# Altium schematic sheet Э3("All Documents",Physical)
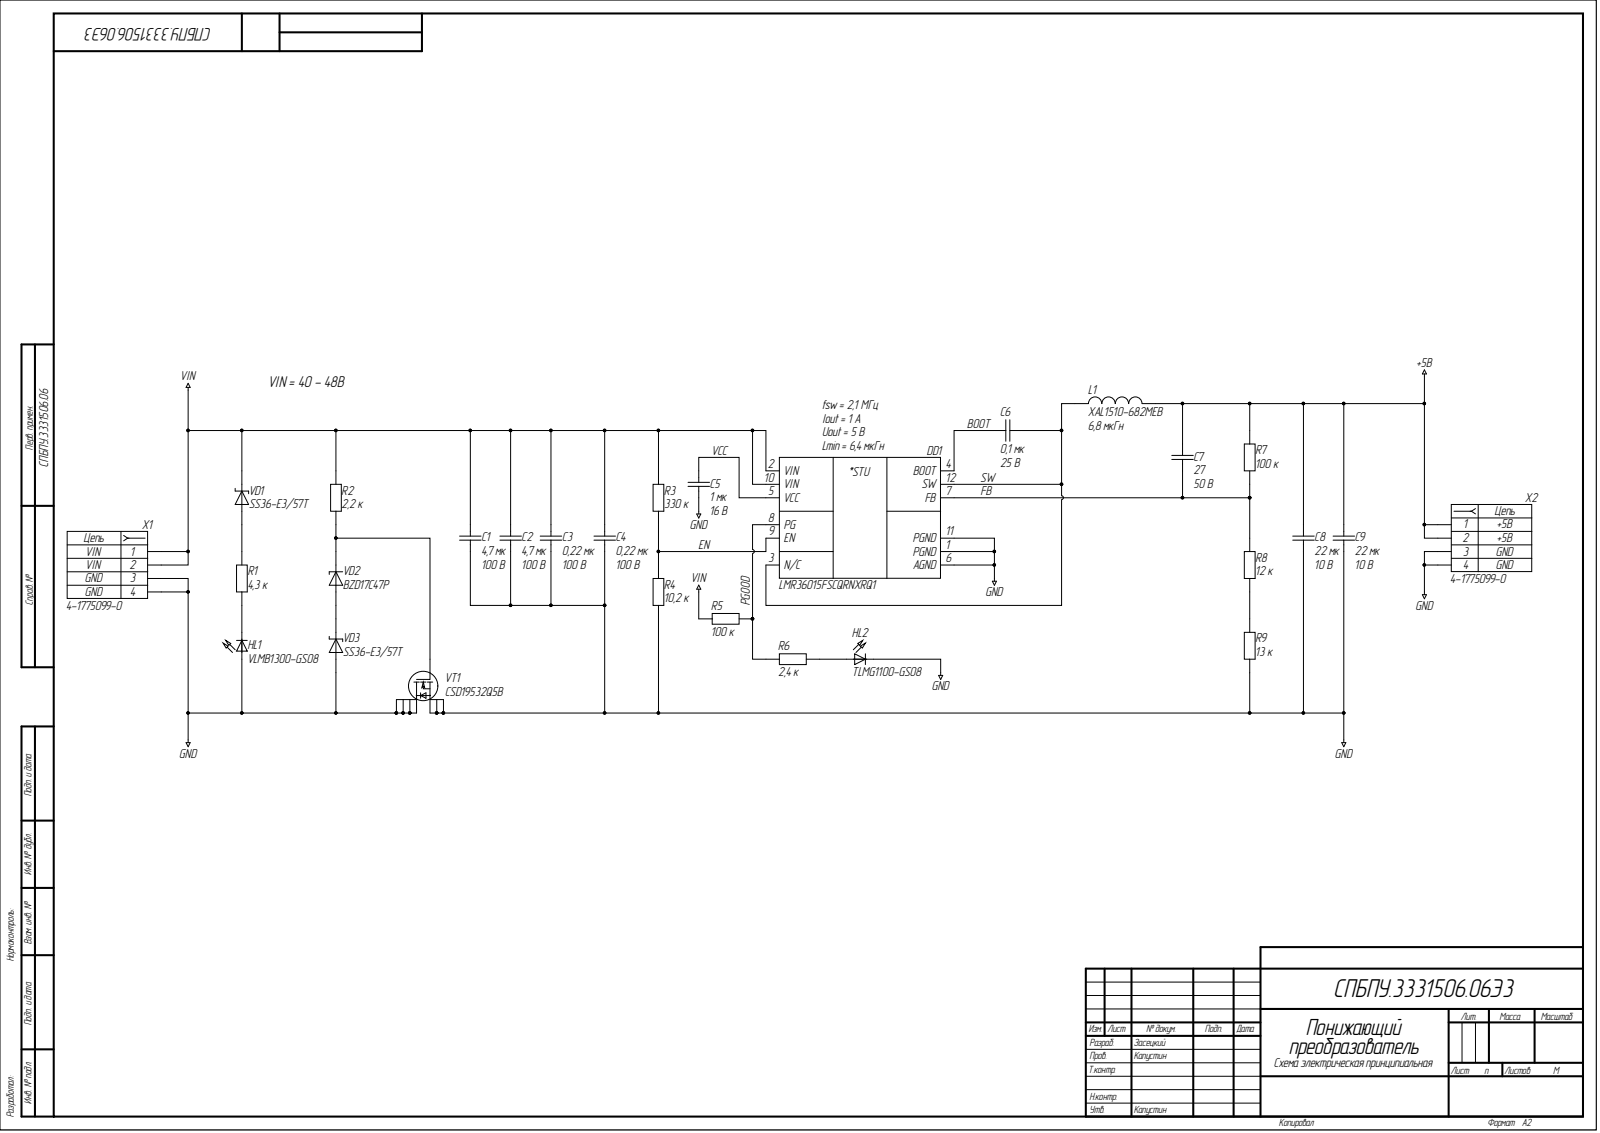
[diagram p0: Э3("All Documents",Physical)]

## Parts
C1  4,7 мк  [C1210]
C2  4,7 мк  Номинальное напряжение: 100 В  [C1210]
C3  0,22 мк  Номинальное напряжение: 100 В  [C0805]
C4  0,22 мк  Номинальное напряжение: 100 В  [C0805]
C5  1 мк  Номинальное напряжение: 16 В  [C0603]
C6  0,1 мк  Номинальное напряжение: 25 В  [C0603]
C7  27  Номинальное напряжение: 50 В  [C0402]
C8  22 мк  [C1206]
C9  22 мк  Номинальное напряжение: 10 В  [C1206]
DD1  LMR36015FSCQRNXRQ1  [LMR36015-Q1]
HL1  VLMB1300-GS08  [LED]
HL2  TLMG1100-GS08  [LED_GREEN]
L1  XAL1510-682MEB  Номинал: 6,8 мкГн  [XAL-2-1620X1520X1100]
R1  4,3 к  [R0603]
R2  2,2 к  [R0603]
R3  330 к  [R0603]
R4  10,2 к  [R0603]
R5  100 к  [R0603]
R6  2,4 к  [R0603]
R7  100 к  [R0603]
R8  12 к  [R0603]
R9  13 к  [R0603]
VD1  SS36-E3/57T  [DO-214AB]
VD2  BZD17C47P  [DO-219AB]
VD3  SS36-E3/57T  [DO-214AB]
VT1  CSD19532Q5B  [SON-8]
X1  4-1775099-0  Contact_4: GND  Contact_2: VIN  [4-1775099-0]
X2  4-1775099-0  Contact_4: GND  Contact_2: +5В  [4-1775099-0]

## Nets
+5В: C7.1 C8.1 C9.1 L1.2 R7.1 X2.1 X2.2
GND: C1.2 C2.2 C3.2 C4.2 C5.2 C8.2 C9.2 DD1.1(PGND) DD1.6(AGND) DD1.11(PGND) HL1.1 HL2.2 R4.1 R9.1 VD3.2 VT1.D VT1.S X1.3 X1.4 X2.3 X2.4
VIN: C1.1 C2.1 C3.1 C4.1 DD1.2(VIN) DD1.10(VIN) R2.2 R3.2 R5.1 VD1.1 X1.1 X1.2
BOOT: C6.2 DD1.4(BOOT)
EN: DD1.9(EN) R3.1 R4.2
FB: C7.2 DD1.7(FB) R7.2 R8.2
PGOOD: DD1.8(PG) R5.2 R6.1
SW: C6.1 DD1.3(N/C) DD1.12(SW) L1.1
VCC: C5.1 DD1.5(VCC)
NetHL1_2: HL1.2 R1.1
NetHL2_1: HL2.1 R6.2
NetR1_2: R1.2 VD1.2
NetR2_1: R2.1 VD2.1 VT1.G
NetR8_1: R8.1 R9.2
NetVD2_2: VD2.2 VD3.1

## Library data of the parts
C1 = c0119: =Описание; Фамилия: Засецкий; Температурный коэффициент емкости: X7R; Рабочие температуры: от –55 °С до +125 °С; Производитель: Samsung electro-mechanics; Отклонение: ±10 %; Описание: Конденсатор чип 1210 4,7 мкФ 100 В ±10 %; Номинальное напряжение: 100 В; Наименование: CL32B475KCIZNWE; Корпус: 1210; Database Table Name: Конденсаторы$; Номинал: 4,7 мк; Напряжение: 100 В; ID: c0119; HelpURL: http://product.samsungsem.com/mlcc/CL32B475KCIZNW.do; Description: Конденсатор чип 1210 4,7 мкФ 100 В ±10 %; Component Kind: Standard; Library Name: Database_Students.DbLib
C2 = c0119: =Описание; Фамилия: Засецкий; Температурный коэффициент емкости: X7R; Рабочие температуры: от –55 °С до +125 °С; Производитель: Samsung electro-mechanics; Отклонение: ±10 %; Описание: Конденсатор чип 1210 4,7 мкФ 100 В ±10 %; Наименование: CL32B475KCIZNWE; Корпус: 1210; Database Table Name: Конденсаторы$; Номинал: 4,7 мк; Напряжение: 100 В; ID: c0119; HelpURL: http://product.samsungsem.com/mlcc/CL32B475KCIZNW.do; Description: Конденсатор чип 1210 4,7 мкФ 100 В ±10 %; Component Kind: Standard; Library Name: Database_Students.DbLib
C3 C4 = c0120: =Описание; Фамилия: Засецкий; Температурный коэффициент емкости: X7R; Рабочие температуры: от –55 °С до +125 °С; Производитель: Samsung electro-mechanics; Отклонение: ±10 %; Описание: Конденсатор чип 0805 0,22 мкФ 100 В ±10 %; Наименование: CL21B224KCFSFNE; Корпус: 0805; Database Table Name: Конденсаторы$; Номинал: 0,22 мк; Напряжение: 100 В; ID: c0120; HelpURL: http://product.samsungsem.com/mlcc/CL21B224KCFSFN.do; Description: Конденсатор чип 0805 0,22 мкФ 100 В ±10 %; Component Kind: Standard; Library Name: Database_Students.DbLib
C5 = c0063: =Описание; Фамилия: Паньков; Температурный коэффициент емкости: X7R; Рабочие температуры: от –55 °С до +125 °С; Производитель: YAGEO Corp.; Отклонение: ±10 %; Описание: Конденсатор чип 0603 1 мкФ 16 В ±10 %; Наименование: CC0603KRX7R7BB105; Корпус: 0603; Database Table Name: Конденсаторы$; Номинал: 1 мк; Напряжение: 16 В; ID: c0063; HelpURL: https://www.yageo.com/upload/media/product/products/datasheet/mlcc/UPY-GPHC_X7R_6.3V-to-50V_20.pdf; Description: Конденсатор чип 0603 1 мкФ 16 В ±10 %; Component Kind: Standard; Library Name: Database_Students.DbLib
C6 = c0033: =Описание; Фамилия: Паньков; Температурный коэффициент емкости: X7R; Рабочие температуры: от –55 °С до +125 °С; Производитель: YAGEO Corp.; Отклонение: ±10 %; Описание: Конденсатор чип 0603 100 нФ 25 В ±10 %; Наименование: CC0603KRX7R8BB104; Корпус: 0603; Database Table Name: Конденсаторы$; Номинал: 0,1 мк; Напряжение: 25 В; ID: c0033; HelpURL: https://www.yageo.com/upload/media/product/products/datasheet/mlcc/UPY-GPHC_X7R_6.3V-to-50V_20.pdf; Description: Конденсатор чип 0603 100 нФ 25 В ±10 %; Component Kind: Standard; Library Name: Database_Students.DbLib
C7 = c0001: =Описание; Фамилия: Соколов; Температурный коэффициент емкости: C0G; Рабочие температуры: от –55 °С до +125 °С; Производитель: Samsung electro-mechanics; Отклонение: ±5 %; Описание: Конденсатор чип 0402 27 пФ 50 В ±5 %; Наименование: CL05C270JB5NNNC; Корпус: 0402; Database Table Name: Конденсаторы$; Номинал: 27; Напряжение: 50 В; ID: c0001; HelpURL: http://product.samsungsem.com/mlcc/CL05C270JB5NNN.do; Description: Конденсатор чип 0402 27 пФ 50 В ±5 %; Component Kind: Standard; Library Name: Database_Students.DbLib
C8 = c0102: =Описание; Фамилия: Паньков; Температурный коэффициент емкости: X7R; Рабочие температуры: от –55 °С до +125 °С; Производитель: YAGEO Corp.; Отклонение: ±10 %; Описание: Конденсатор чип 0603 22 мкФ 10 В ±10 %; Номинальное напряжение: 10 В; Наименование: CC1206KRX7R6BB226; Корпус: 1206; Database Table Name: Конденсаторы$; Номинал: 22 мк; Напряжение: 10 В; ID: c0102; HelpURL: https://www.yageo.com/upload/media/product/productsearch/datasheet/mlcc/UPY-GPHC_X7R_6.3V-to-50V_20.pdf; Description: Конденсатор чип 0603 22 мкФ 10 В ±10 %; Component Kind: Standard; Library Name: Database_Students.DbLib
C9 = c0102: =Описание; Фамилия: Паньков; Температурный коэффициент емкости: X7R; Рабочие температуры: от –55 °С до +125 °С; Производитель: YAGEO Corp.; Отклонение: ±10 %; Описание: Конденсатор чип 0603 22 мкФ 10 В ±10 %; Наименование: CC1206KRX7R6BB226; Корпус: 1206; Database Table Name: Конденсаторы$; Номинал: 22 мк; Напряжение: 10 В; ID: c0102; HelpURL: https://www.yageo.com/upload/media/product/productsearch/datasheet/mlcc/UPY-GPHC_X7R_6.3V-to-50V_20.pdf; Description: Конденсатор чип 0603 22 мкФ 10 В ±10 %; Component Kind: Standard; Library Name: Database_Students.DbLib
DD1 = dd0002: =Описание; Фамилия: Засецкий; Рабочие температуры: от -40 °С до +125 °С; Производитель: Texas Instruments; Описание: Преобразователь напряжения понижающий VQFN12; Корпус: VQFN-12; Database Table Name: Микросхемы$; Наименование: LMR36015FSCQRNXRQ1; Library Name: MyDatabase.DbLib; ID: dd0002; HelpURL: https://www.ti.com/lit/ds/symlink/lmr36015-q1.pdf?ts=1605706736340; Description: Преобразователь напряжения понижающий VQFN12; Component Kind: Standard
HL1 = hl0003: =Описание; Фамилия: Засецкий; Рабочие температуры: от -35 °С до +85 °С; Производитель: Vishay; Примечание: Синий; Описание: Светодиод синий чип 0603; Номинальный ток: 20 мА; Корпус: 0603; Database Table Name: Светодиоды$; Наименование: VLMB1300-GS08; Library Name: MyDatabase.DbLib; ID: hl0003; HelpURL: https://www.vishay.com/docs/82437/vlmo1300.pdf; Description: Светодиод синий чип 0603; Component Kind: Standard
HL2 = hl0002: =Описание; Фамилия: Засецкий; Рабочие температуры: от -40 °С до +100 °С; Производитель: Vishay; Примечание: Зелёный; Описание: Светодиод зелёный чип 0603; Номинальный ток: 30 мА; Корпус: 0603; Database Table Name: Светодиоды$; Наименование: TLMG1100-GS08; Library Name: MyDatabase.DbLib; ID: hl0002; HelpURL: https://www.vishay.com/docs/83173/tlmb1100.pdf; Description: Светодиод зелёный чип 0603; Component Kind: Standard
L1 = l0007: =Описание; Фамилия: Ларионов; Ток насыщения: 36 А; Резонансная частота: 11,5 МГц; Рабочие температуры: от –40 °С до +125 °С; Рабочее напряжение: от 0 В до 60 В; Производитель: Coilcraft Inc.; Отклонение: ±20 %; Описание: Катушка индуктивности 6,8 мкГн ±20 %; Номинальный ток (при нагреве на 20 °С/40 °С): 19 А; Номинальное активное сопротивление: 4,17 мОм; Максимальное активное сопротивление: 4,6 мОм; Корпус: 16,2 x 15,2 x 11; Database Table Name: Катушки индуктивности$; Наименование: XAL1510-682MEB; Индуктивность: 6,8 мкГн; ID: l0007; HelpURL: https://www.coilcraft.com/en-us/products/power/shielded-inductors/molded-inductor/xal/xal1510; Description: Катушка индуктивности 6,8 мкГн ±20 %; Component Kind: Standard; Library Name: Database_Students.DbLib
R1 = r0047: =Описание; Фамилия: Паньков; Рабочие температуры: от –55 °С до +155 °С; Производитель: YAGEO Corp.; Примечание: Ряд E24; Отклонение: ±1 %; Описание: Резистор чип 0603 4,3 кОм ±1 % 0,1 Вт; Номинальный ток: 1 А; Номинальное напряжение: 75 В; Наименование: RC0603FR-074K3L; Корпус: 0603; Database Table Name: Резисторы$; Номинал: 4,3 к; ID: r0047; HelpURL: https://www.yageo.com/upload/media/product/productsearch/datasheet/rchip/PYu-RC_Group_51_RoHS_L_11.pdf; Description: Резистор чип 0603 4,3 кОм ±1 % 0,1 Вт; Component Kind: Standard; Library Name: Database_Students.DbLib
R2 = r0040: =Описание; Фамилия: Паньков; Рабочие температуры: от –55 °С до +155 °С; Производитель: YAGEO Corp.; Примечание: Ряд E24; Отклонение: ±1 %; Описание: Резистор чип 0603 2,2 кОм ±1 % 0,1 Вт; Номинальный ток: 1 А; Номинальное напряжение: 75 В; Наименование: RC0603FR-072K2L; Корпус: 0603; Database Table Name: Резисторы$; Номинал: 2,2 к; ID: r0040; HelpURL: https://www.yageo.com/upload/media/product/productsearch/datasheet/rchip/PYu-RC_Group_51_RoHS_L_11.pdf; Description: Резистор чип 0603 2,2 кОм ±1 % 0,1 Вт; Component Kind: Standard; Library Name: Database_Students.DbLib
R3 = r0113: =Описание; Фамилия: Паньков; Рабочие температуры: от –55 °С до +155 °С; Производитель: YAGEO Corp.; Примечание: Ряд E24; Отклонение: ±1 %; Описание: Резистор чип 0603 330 кОм ±1 % 0,1 Вт; Номинальный ток: 1 А; Номинальное напряжение: 75 В; Наименование: RC0603FR-07330KL; Корпус: 0603; Database Table Name: Резисторы$; Номинал: 330 к; ID: r0113; HelpURL: https://www.yageo.com/upload/media/product/productsearch/datasheet/rchip/PYu-RC_Group_51_RoHS_L_11.pdf; Description: Резистор чип 0603 330 кОм ±1 % 0,1 Вт; Component Kind: Standard; Library Name: Database_Students.DbLib
R4 = r0061: =Описание; Фамилия: Жернаков; Рабочие температуры: от –55 °С до +155 °С; Производитель: YAGEO Corp.; Примечание: Ряд E24; Отклонение: ±1 %; Описание: Резистор чип 0603 10,2 кОм ±1 % 0,1 Вт; Номинальный ток: 1 А; Номинальное напряжение: 75 В; Наименование: RC0603FR-0710K2L; Корпус: 0603; Database Table Name: Резисторы$; Номинал: 10,2 к; ID: r0061; HelpURL: https://www.yageo.com/upload/media/product/productsearch/datasheet/rchip/PYu-RC_Group_51_RoHS_L_11.pdf; Description: Резистор чип 0603 10,2 кОм ±1 % 0,1 Вт; Component Kind: Standard; Library Name: Database_Students.DbLib
R5 R7 = r0094: =Описание; Фамилия: Водорезов; Рабочие температуры: от –55 °С до +155 °С; Производитель: YAGEO Corp.; Примечание: Ряд E24; Отклонение: ±1 %; Описание: Резистор чип 0603 100 кОм ±1 % 0,1 Вт; Номинальный ток: 1 А; Номинальное напряжение: 75 В; Наименование: RC0603FR-07100KL; Корпус: 0603; Database Table Name: Резисторы$; Номинал: 100 к; ID: r0094; HelpURL: https://www.yageo.com/upload/media/product/productsearch/datasheet/rchip/PYu-RC_Group_51_RoHS_L_11.pdf; Description: Резистор чип 0603 100 кОм ±1 % 0,1 Вт; Component Kind: Standard; Library Name: Database_Students.DbLib
R6 = r0041: =Описание; Фамилия: Паньков; Рабочие температуры: от –55 °С до +155 °С; Производитель: YAGEO Corp.; Примечание: Ряд E24; Отклонение: ±1 %; Описание: Резистор чип 0603 2,4 кОм ±1 % 0,1 Вт; Номинальный ток: 1 А; Номинальное напряжение: 75 В; Наименование: RC0603FR-072K4L; Корпус: 0603; Database Table Name: Резисторы$; Номинал: 2,4 к; ID: r0041; HelpURL: https://www.yageo.com/upload/media/product/productsearch/datasheet/rchip/PYu-RC_Group_51_RoHS_L_11.pdf; Description: Резистор чип 0603 2,4 кОм ±1 % 0,1 Вт; Component Kind: Standard; Library Name: Database_Students.DbLib
R8 = r0063: =Описание; Фамилия: Паньков; Рабочие температуры: от –55 °С до +155 °С; Производитель: YAGEO Corp.; Примечание: Ряд E24; Отклонение: ±1 %; Описание: Резистор чип 0603 12 кОм ±1 % 0,1 Вт; Номинальный ток: 1 А; Номинальное напряжение: 75 В; Наименование: RC0603FR-0712KL; Корпус: 0603; Database Table Name: Резисторы$; Номинал: 12 к; ID: r0063; HelpURL: https://www.yageo.com/upload/media/product/productsearch/datasheet/rchip/PYu-RC_Group_51_RoHS_L_11.pdf; Description: Резистор чип 0603 12 кОм ±1 % 0,1 Вт; Component Kind: Standard; Library Name: Database_Students.DbLib
R9 = r0065: =Описание; Фамилия: Жернаков; Рабочие температуры: от –55 °С до +155 °С; Производитель: YAGEO Corp.; Примечание: Ряд E24; Отклонение: ±1 %; Описание: Резистор чип 0603 13 кОм ±1 % 0,1 Вт; Номинальный ток: 1 А; Номинальное напряжение: 75 В; Наименование: RC0603FR-0713KL; Корпус: 0603; Database Table Name: Резисторы$; Номинал: 13 к; ID: r0065; HelpURL: https://www.yageo.com/upload/media/product/productsearch/datasheet/rchip/PYu-RC_Group_51_RoHS_L_11.pdf; Description: Резистор чип 0603 13 кОм ±1 % 0,1 Вт; Component Kind: Standard; Library Name: Database_Students.DbLib
VD1 VD3 = vd0004: =Описание; Фамилия: Жернаков; Рабочие температуры: от –55 °С до +150 °С; Производитель: Vishay Intertechnology, Inc.; Пиковое прямое напряжение: 0,75 В; Описание: Диод Шоттки 60 В 3 А; Макс допустимый средний прямой ток: 3 А; Импульсное обратное напряжение: 60 В; Database Table Name: Диоды$; Наименование: SS36-E3/57T; Корпус: DO-214AB; ID: vd0004; HelpURL: https://www.vishay.com/product?docid=88751; Description: Диод Шоттки 60 В 3 А; Component Kind: Standard; Library Name: Database_Students.DbLib
VD2 = vd0028: =Описание; Фамилия: Паньков; Разброс напряжения стабилизации: 44,0...50,0 В; Рабочие температуры: от –55 °С до +150 °С; Производитель: Vishay Intertechnology, Inc.; Описание: Стабилитрон 47 В; Номинальный ток стабилизации: 10 мА; Номинальное напряжение стабилизации: 47 В; Database Table Name: Стабилитроны$; Наименование: BZD17C47P; Корпус: DO-219AB; ID: vd0028; HelpURL: https://www.vishay.com/product?docid=85161; Description: Стабилитрон 47 В; Component Kind: Standard; Library Name: Database_Students.DbLib
VT1 = vt0001: =Описание; Фамилия: Жернаков; Ток стока: 17 А; Сопротивление сток — исток: 0,0057 Ом; Рабочие температуры: от –55 °С до +150 °С; Производитель: Texas Instruments; Описание: Транзистор полевой N-канальный 100 В 17 А; Напряжение сток — исток: 100 В; Напряжение затвор — исток: ±20 В; Database Table Name: Транзисторы$; Наименование: CSD19532Q5B; Корпус: SON-8; ID: vt0001; HelpURL: https://www.ti.com/product/CSD19532Q5B; Description: Транзистор полевой N-канальный 100 В 17 А; Component Kind: Standard; Library Name: Database_Students.DbLib
X1 X2 = x0004: =Описание; Фамилия: Ларионов; Производитель: TE Connectivity; Описание: ATX Power 4 pin гнездо прямой; Database Table Name: Разъемы$; Наименование: 4-1775099-0; ID: x0004; HelpURL: https://www.te.com/usa-en/product-4-1775099-0.html; Description: ATX Power 4 pin гнездо прямой; Contact_3: GND; Component Kind: Standard; Library Name: Database_Students.DbLib
  X1: Contact_1: VIN
  X2: Contact_1: +5В
